# Altium schematic sheet Power.SchDoc
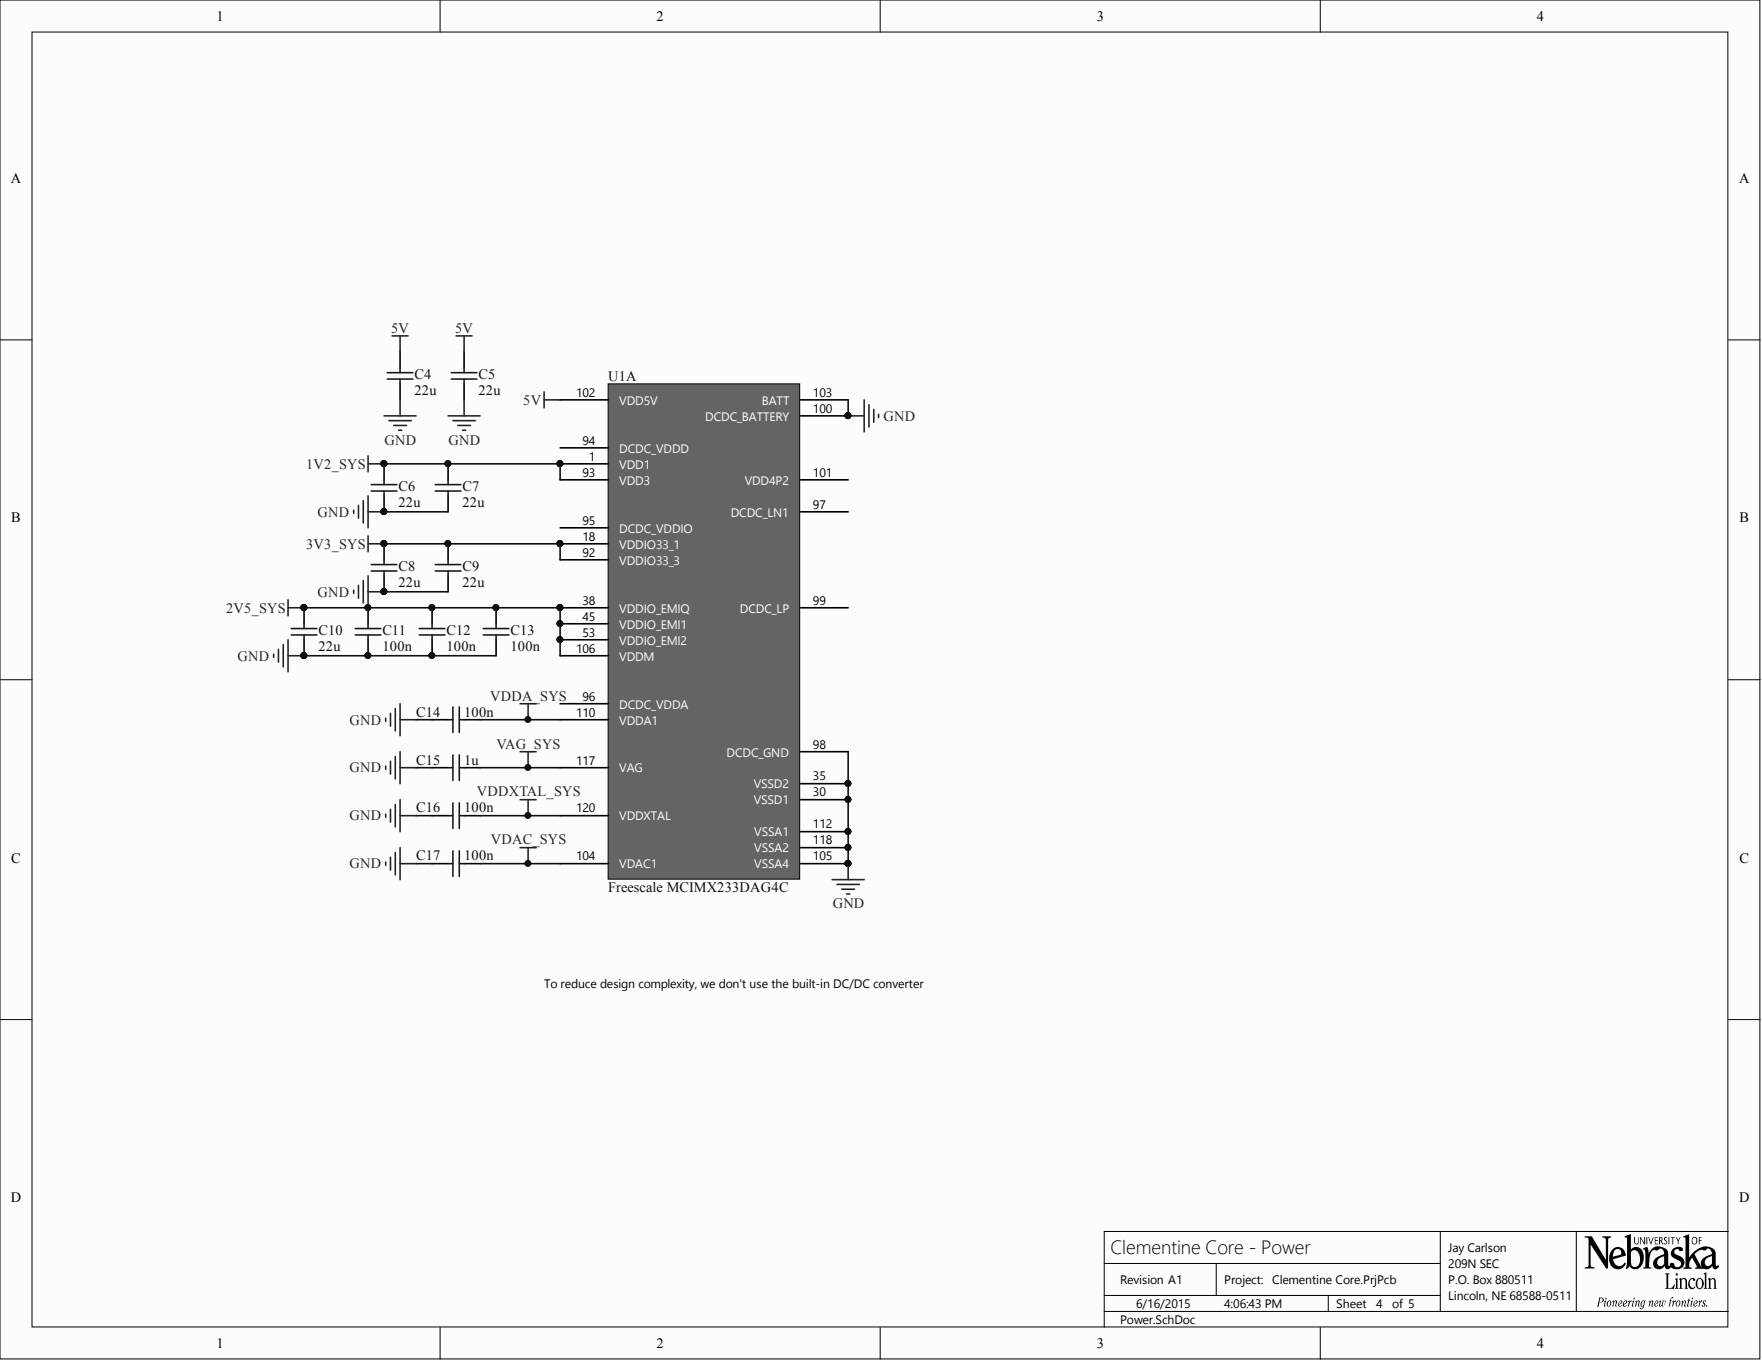
[diagram p0: Power.SchDoc]

## Parts
C4  22u  [CAPC1608X90X35ML10T15]
C5  22u  [CAPC1608X90X35ML10T15]
C6  22u  [CAPC1608X90X35ML10T15]
C7  22u  [CAPC1608X90X35ML10T15]
C8  22u  [CAPC1608X90X35ML10T15]
C9  22u  [CAPC1608X90X35ML10T15]
C10  22u  [CAPC1608X90X35ML10T15]
C11  100n  [CAPC1005X55X25LL05T10]
C12  100n  [CAPC1005X55X25LL05T10]
C13  100n  [CAPC1005X55X25LL05T10]
C14  100n  [CAPC1005X55X25LL05T10]
C15  1u  [CAPC1005X56X25NL10T15]
C16  100n  [CAPC1005X55X25LL05T10]
C17  100n  [CAPC1005X55X25LL05T10]
U1  Freescale MCIMX233DAG4C  Manufacturer Part Number: MCIMX233DAG4C  [PCB-1234233-1]  (part A)

## Nets
1V2_SYS: C6.2 C7.2 U1.1(VDD1) U1.93(VDD3)
2V5_SYS: C10.2 C11.2 C12.2 C13.2 U1.38(VDDIO_EMIQ) U1.45(VDDIO_EMI1) U1.53(VDDIO_EMI2) U1.106(VDDM)
3V3_SYS: C8.2 C9.2 U1.18(VDDIO33_1) U1.92(VDDIO33_3)
5V: C4.2 C5.2 U1.102(VDD5V)
GND: C4.1 C5.1 C6.1 C7.1 C8.1 C9.1 C10.1 C11.1 C12.1 C13.1 C14.2 C15.1 C16.1 C17.1 U1.30(VSSD1) U1.35(VSSD2) U1.98(DCDC_GND) U1.100(DCDC_BATTERY) U1.103(BATT) U1.105(VSSA4) U1.112(VSSA1) U1.118(VSSA2)
VAG_SYS: C15.2 U1.117(VAG)
VDAC_SYS: C17.2 U1.104(VDAC1)
VDDA_SYS: C14.1 U1.110(VDDA1)
VDDXTAL_SYS: C16.2 U1.120(VDDXTAL)

Not connected: U1 94(DCDC_VDDD) 95(DCDC_VDDIO) 96(DCDC_VDDA) 97(DCDC_LN1) 99(DCDC_LP) 101(VDD4P2)

## Library data of the parts
C4-C10 = CMP-133494-2: Cap Ceramic 1uF 25V X5R 20% SMD 0603 85C Paper T/R; Manufacturer Part Number: GRM188R61E105MA12D; CiivaID: 133494; Rated Voltage: 25VDC; Category: Passives › Capacitor › Ceramic capacitor; Ciiva URL: https://ciiva.com/part/grm188r61e105ma12d-133494; Component Kind: Standard; Diameter: N/R; Height: 0.8mm; Length: 1.6mm; Library Name: CommunityVault; Maximum operating temperature: 85 C; Minimum operating temperature: -55 C; Size: 1.6 X 0.8 X 0.8mm; Temperature coefficient: X5R; Tolerance: 20%; Width: 0.8mm
C11-C14 C16 C17 = CMP-19299678-1: CAP CER 0.1UF 16V Y5V 0402; Manufacturer: Murata; Manufacturer Part Number: GRM155F51C104ZA01D; Brand: Murata Electronics; Capacitance - nF: 100 nF; Capacitance - pF: 100000 pF; Case Height: 0.5 mm; Case Length: 1 mm; Temperature Coefficient / Code: - 82 %, + 22 %; Tolerance: - 20 %, + 80 %; Type: General Purpose MLCC; Capacitance: 0.1 uF; Case Code - in: 0402; Case Code - mm: 1005; Case Width: 0.5 mm; CiivaID: 19299678; Ciiva URL: https://ciiva.com/part/grm155f51c104za01d-19299678; Component Kind: Standard; Dielectric: Y5V; Dimensions: 0.5 mm W x 1 mm L x 0.5 mm H; Factory Pack Quantity: 10000; Library Name: CommunityVault; Maximum Operating Temperature: + 85 C; Minimum Operating Temperature: - 30 C; Operating Temperature Range: - 30 C to + 85 C; Package / Case: 0402 (1005 metric); Packaging: Reel; Product: General Type MLCCs; Product Category: Multilayer Ceramic Capacitors MLCC - SMD/SMT; RoHS: Details; Series: GRM; Termination Style: SMD/SMT; Voltage Rating: 16 V; Voltage Rating DC: 16 V
C15 = CMP-125977-1: AVX   04026D105KAT2A   CAP, MLCC, X5R, 1UF, 6.3V, 0402; Case Height: 0.56 mm; Case Length: 1 mm; Case Width: 0.5 mm; CiivaID: 125977; Temperature Coefficient / Code: +/- 15 %; Tolerance: 10 %; Type: Commercial Surface Mount Chip; Brand: AVX; Capacitance: 1 uF; Case Code - in: 0402; Case Code - mm: 1005; Ciiva URL: https://ciiva.com/part/04026d105kat2a-125977; Component Kind: Standard; Dielectric: X5R; Dimensions: 0.5 mm W x 1 mm L x 0.56 mm H; Factory Pack Quantity: 10000; Library Name: CommunityVault; Manufacturer: AVX; Manufacturer Part Number: 04026D105KAT2A; Maximum Operating Temperature: + 85 C; Minimum Operating Temperature: - 55 C; Operating Temperature Range: - 55 C to + 85 C; Package / Case: 0402 (1005 metric); Packaging: Reel; Product: General Type MLCCs; Product Category: Multilayer Ceramic Capacitors MLCC - SMD/SMT; RoHS: Details; Series: 0402; Termination Style: SMD/SMT; Voltage Rating: 6.3 V; Voltage Rating DC: 6.3 V
U1 = CMP-1234233-6: MPU 32BIT I.MX233 128LQFP; Processing Unit: Microprocessor; Rad Hardened: No; Screening Level: Commercial; CiivaID: 1234233; Ciiva URL: https://ciiva.com/part/mcimx233dag4c-1234233; Component Kind: Standard; Library Name: CommunityVault; Mounting: Surface Mount; Package Type: LQFP; Packaging: Tray; Pin Count: 128; Technology: 0.09um
